# Altium schematic sheet lv_io.SchDoc(lv_io)
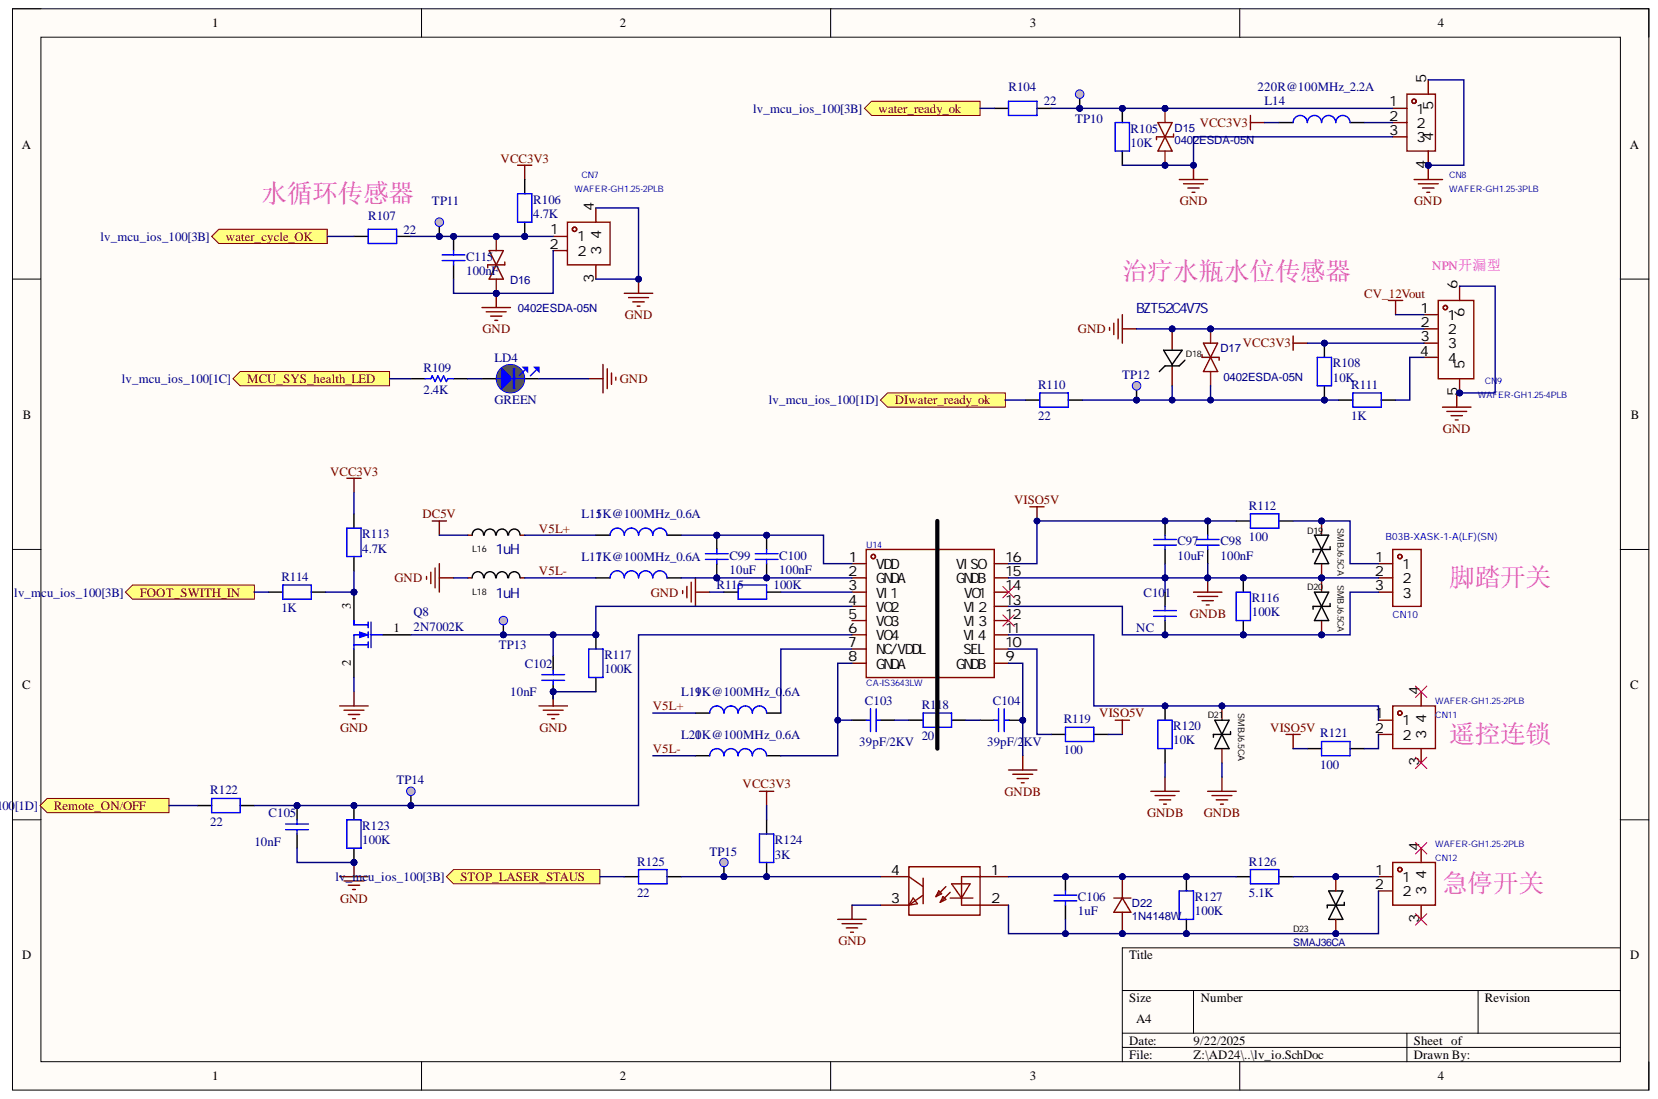
[diagram p0: lv_io.SchDoc]

## Parts
C97  10uF  [C0805]
C98  100nF  [C0603]
C99  10uF  [C0805]
C100  100nF  [C0603]
C101  NC  [C0603]
C102  10nF  [C0603]
C103  39pF/2KV  [1206]
C104  39pF/2KV  [1206]
C105  10nF  [C0603]
C106  1uF  [C0603]
C115  100nF  [C0603]
CN7  WAFER-GH1.25-2PLB  [CONN-TH_2P-P1.25_XUNPU_WAFER-GH1.25-2PLB]
CN8  WAFER-GH1.25-3PLB  [CONN-SMD_3P-P1.25_XUNPU_WAFER-GH1.25-3PLB]
CN9  WAFER-GH1.25-4PLB  [CONN-SMD_4P-P1.25_XUNPU_WAFER-GH1.25-4PLB]
CN10  B03B-XASK-1-A(LF)(SN)  [CONN-TH_B03B-XASK-1-A-LF-SN]
CN11  WAFER-GH1.25-2PLB  [CONN-TH_2P-P1.25_XUNPU_WAFER-GH1.25-2PLB]
CN12  WAFER-GH1.25-2PLB  [CONN-TH_2P-P1.25_XUNPU_WAFER-GH1.25-2PLB]
D15  0402ESDA-05N  [D0402-BI]
D16  0402ESDA-05N  [D0402-BI]
D17  0402ESDA-05N  [D0402-BI]
D18  BZT52C4V7S  [SOD-323_L1.7-W1.3-LS2.5-FD]
D19  SMBJ6.5CA  [SMB_L4.6-W3.6-LS5.3-BI]
D20  SMBJ6.5CA  [SMB_L4.6-W3.6-LS5.3-BI]
D21  SMBJ6.5CA  [SMB_L4.6-W3.6-LS5.3-BI]
D22  1N4148W  [SOD-123_L2.8-W1.8-LS3.7-RD]
D23  SMAJ36CA  [SMA_L4.4-W2.6-LS5.0-BI]
L14  220R@100MHz_2.2A  [L0603]
L15  1K@100MHz_0.6A  [L0603]
L16  1uH  [R0805]
L17  1K@100MHz_0.6A  [L0603]
L18  1uH  [R0805]
L19  1K@100MHz_0.6A  [L0603]
L20  1K@100MHz_0.6A  [L0603]
LD4  value GREEN  [LED_0603_GREEN]  (hidden comment: LED_green)
Q8  2N7002K  [SOT-23(SOT-23-3)]
R104  22  [R0402]
R105  10K  [R0603]
R106  4.7K  [R0402]
R107  22  [R0402]
R108  10K  [R0603]
R109  2.4K  [R0402]
R110  22  [R0402]
R111  1K  value 10K  [R0603]
R112  100  [R0603]
R113  4.7K  [R0402]
R114  1K  [R0402]
R115  100K  [R0402]
R116  100K  [R0603]
R117  100K  [R0402]
R118  20  [0805]
R119  100  [R0603]
R120  10K  [R0603]
R121  100  [R0603]
R122  22  [R0402]
R123  100K  [R0402]
R124  3K  [R0402]
R125  22  [R0402]
R126  5.1K  [R0603]
R127  100K  [R0603]
TP10  Test Point  [TP_SMD_1MM]  (value not drawn)  (no BOM)
TP11  Test Point  [TP_SMD_1MM]  (value not drawn)  (no BOM)
TP12  Test Point  [TP_SMD_1MM]  (value not drawn)  (no BOM)
TP13  Test Point  [TP_SMD_1MM]  (value not drawn)  (no BOM)
TP14  Test Point  [TP_SMD_1MM]  (value not drawn)  (no BOM)
TP15  Test Point  [TP_SMD_1MM]  (value not drawn)  (no BOM)
U14  CA-IS3643LW  [SOIC-16_L10.3-W7.5-P1.27-LS10.3-BL-1]
U15  EL817S1(C)(TU)-F  [OPTO-SMD-4_L4.6-W6.5-P2.54-LS10.3-TL]  (value not drawn)

## Ports
DIwater_ready_ok, FOOT_SWITH_IN, MCU_SYS_health_LED, Remote_ON/OFF, STOP_LASER_STAUS, water_cycle_OK, water_ready_ok

## Nets
CV_12Vout: CN9.1
DC5V: L16.1
GND: C102.2 C105.2 C115.1 CN7.2 CN7.3 CN7.4 CN8.3 CN8.4 CN8.5 CN9.2 CN9.5 CN9.6 D15.1 D16.1 D17.1 D18.2 L18.1 LD4.2 Q8.2 R105.1 R115.2 R117.1 R123.1 U15.3
GNDB: C97.2 C98.1 C101.2 C104.2 CN10.2 D19.1 D20.2 D21.1 R116.2 R120.1 U14.9(GNDB) U14.15(GNDB)
VCC3V3: CN9.3 L14.2 R106.1 R108.1 R113.2 R124.2
VISO5V: C97.1 C98.2 R112.2 R119.1 R121.1 U14.16(VISO)
DIwater_ready_ok: R110.1 ; port DIwater_ready_ok
FOOT_SWITH_IN: R114.2 ; port FOOT_SWITH_IN
MCU_SYS_health_LED: R109.2 ; port MCU_SYS_health_LED
Remote_ON/OFF: R122.1 ; port Remote_ON/OFF
STOP_LASER_STAUS: R125.1 ; port STOP_LASER_STAUS
V5L+: L15.2 L16.2 L19.2
V5L-: L17.2 L18.2 L20.2
water_cycle_OK: R107.1 ; port water_cycle_OK
water_ready_ok: R104.1 ; port water_ready_ok
NetC99_1: C99.1 C100.2 L15.1 U14.1(VDD)
NetC99_2: C99.2 C100.1 L17.1 U14.2(GNDA)
NetC101_1: C101.1 CN10.3 D20.1 R116.1 U14.13(VI2)
NetC102_1: C102.1 Q8.1 R117.2 TP13.1 U14.4(VO2)
NetC103_1: C103.1 L20.1 U14.8(GNDA)
NetC103_2: C103.2 R118.2
NetC104_1: C104.1 R118.1
NetC105_1: C105.1 R122.2 R123.2 TP14.1 U14.6(VO4)
NetC106_1: C106.1 CN12.2 D22.2 D23.2 R127.2 U15.2
NetC106_2: C106.2 D22.1 R126.2 R127.1 U15.1
NetC115_2: C115.2 CN7.1 D16.2 R106.2 R107.2 TP11.1
NetCN8_1: CN8.1 D15.2 R104.2 R105.2 TP10.1
NetCN8_2: CN8.2 L14.1
NetCN9_4: CN9.4 R111.2
NetCN10_1: CN10.1 D19.2 R112.1
NetCN11_1: CN11.1 D21.2 R120.2 U14.11(VI4)
NetCN11_2: CN11.2 R121.2
NetCN12_1: CN12.1 D23.1 R126.1
NetD17_2: D17.2 D18.1 R108.2 R110.2 R111.1 TP12.1
NetL19_1: L19.1 U14.7(NC/VDDL)
NetLD4_1: LD4.1 R109.1
NetQ8_3: Q8.3 R113.1 R114.1
NetR115_1: R115.1 U14.3(VI1)
NetR119_2: R119.2 U14.10(SEL)
NetR124_1: R124.1 R125.2 TP15.1 U15.4

Not connected: CN11 3 4; CN12 3 4; U14 5(VO3) 12(VI3) 14(VO1)

## Library data of the parts
C97 = Capacitor_1: 50V, X5R, 20%; Manufacturer: muRata; Manufacturer_Part_Number: GRM188R61E106MA73D; Component Kind: Standard; ComponentLink1Description: Yageo_Capacitor_X5R-series.pdf; ComponentLink1URL: http://tunmcdsvn.tun.st.com/Component_Datasheet_Library/Trunk/Capacitors/Yageo_Multilayer_capacitor_X5R_series_100pF_to_100uF; Library Name: HiPin_Library_AD.IntLib
C98 C100 C101 C115 = Capacitor_1: 50V, X7R, 10%; Manufacturer: muRata; Manufacturer_Part_Number: GRM188R71H104KA93D; Component Kind: Standard; ComponentLink1Description: Yageo_Capacitor_X5R-series.pdf; ComponentLink1URL: http://tunmcdsvn.tun.st.com/Component_Datasheet_Library/Trunk/Capacitors/Yageo_Multilayer_capacitor_X5R_series_100pF_to_100uF; Library Name: HiPin_Library_AD.IntLib
C99 = Capacitor_1: 50V, X5R, 20%; Manufacturer: muRata; Manufacturer_Part_Number: GRM21BR61H106KE43L; Component Kind: Standard; ComponentLink1Description: Yageo_Capacitor_X5R-series.pdf; ComponentLink1URL: http://tunmcdsvn.tun.st.com/Component_Datasheet_Library/Trunk/Capacitors/Yageo_Multilayer_capacitor_X5R_series_100pF_to_100uF; Library Name: HiPin_Library_AD.IntLib
C102 C105 = Capacitor_1: 50V, X5R, 10%; Manufacturer: muRata; Manufacturer_Part_Number: GRM188R61H105KAALD; Component Kind: Standard; ComponentLink1Description: Yageo_Capacitor_X5R-series.pdf; ComponentLink1URL: http://tunmcdsvn.tun.st.com/Component_Datasheet_Library/Trunk/Capacitors/Yageo_Multilayer_capacitor_X5R_series_100pF_to_100uF; Library Name: HiPin_Library_AD.IntLib
C103 C104 = Capacitor_3: 2KV, NP0, 5%; Internal_Part_Number: MCD-00008; Manufacturer: YAGEO; Manufacturer_Part_Number: CC1206JKNPODBN390; Component Kind: Standard; Library Name: HiPin_Library_AD.IntLib
C106 = Capacitor_1: 50V, X5R, 10%; Manufacturer: YAGEO; Manufacturer_Part_Number: CC0603KRX7R9BB105; Component Kind: Standard; Library Name: HiPin_Library_AD.IntLib; Package: C0603
CN7 CN11 CN12 = WAFER-GH1.25-2PLB: 插座系列: GH,  立贴结构: 1x2P, 间距: 1.25mm; Manufacturer: XUNPU(讯普); Add into BOM: yes; Current Rating: 1A; Number of PINs Per Row: 2; Plastic Material: LCP; Supplementary Features: 辅助焊脚; Voltage Rating: 125V; X-Length of Bottom Edge on Board (Spacing Line): 5.75mm; Y-Width of Bottom Edge on Board: 4.95mm; Z-Height of the Board: 4.2mm; Color: 米色; Component Kind: Standard; Contact Material: 黄铜; Contact Plating: -; Convert to PCB: yes; Datasheet: https://atta.szlcsc.com/upload/public/pdf/source/20220527/44F824AAC8C2B617205E15955FF9C23D.pdf; Device: WAFER-GH1.25-2PLB; Flame Retardant Rating: -; JLCPCB Part Class: Extended Part; LCSC Part Name: 1x2P 间距:1.25mm 立贴 系列:GH; Library Name: HiPin_Library_AD.IntLib; Manufacturer Part: WAFER-GH1.25-2PLB; Mounting Type: 立贴; Number of Pins: 2P; Number of Rows: 1; Operating Temperature: -25℃~+85℃; PCB3D: CONN-TH_2P-P1.25_XUNPU_WAFER-GH1.25-2PLB; Pins Structure: 1x2P; Pitch: 1.25mm; Reference Series: GH; Row Spacing: -; Supplier: LCSC; Supplier Footprint: SMD,P=1.25mm; Supplier Part: C3029368; Symbol: WAFER-GH1.25-2PLB
CN8 = WAFER-GH1.25-3PLB: 插座系列: GH,  立贴结构: 1x3P, 间距: 1.25mm; Manufacturer: XUNPU(讯普); Add into BOM: yes; Current Rating: 1A; Number of PINs Per Row: 3; Plastic Material: LCP; Supplementary Features: 辅助焊脚; Voltage Rating: 125V; X-Length of Bottom Edge on Board (Spacing Line): 7mm; Y-Width of Bottom Edge on Board: 4.95mm; Z-Height of the Board: 4.2mm; Color: 米色; Component Kind: Standard; Contact Material: 黄铜; Contact Plating: -; Convert to PCB: yes; Datasheet: https://atta.szlcsc.com/upload/public/pdf/source/20220527/44F824AAC8C2B617205E15955FF9C23D.pdf; Device: WAFER-GH1.25-3PLB; Flame Retardant Rating: -; JLCPCB Part Class: Extended Part; LCSC Part Name: 1x3P 间距:1.25mm 立贴 系列:GH; Library Name: HiPin_Library_AD.IntLib; Manufacturer Part: WAFER-GH1.25-3PLB; Mounting Type: 立贴; Number of Pins: 3P; Number of Rows: 1; Operating Temperature: -25℃~+85℃; PCB3D: CONN-SMD_3P-P1.25_XUNPU_WAFER-GH1.25-3PLB; Pins Structure: 1x3P; Pitch: 1.25mm; Reference Series: GH; Row Spacing: -; Supplier: LCSC; Supplier Footprint: SMD,P=1.25mm; Supplier Part: C3029369; Symbol: WAFER-GH1.25-3PLB
CN9 = WAFER-GH1.25-4PLB: 插座系列: GH,  立贴结构: 1x4P, 间距: 1.25mm; Manufacturer: XUNPU(讯普); Add into BOM: yes; Current Rating: 1A; Number of PINs Per Row: 4; Plastic Material: LCP; Supplementary Features: 辅助焊脚; Voltage Rating: 125V; X-Length of Bottom Edge on Board (Spacing Line): 8.25mm; Y-Width of Bottom Edge on Board: 4.95mm; Z-Height of the Board: 4.2mm; Color: 米色; Component Kind: Standard; Contact Material: 黄铜; Contact Plating: -; Convert to PCB: yes; Datasheet: https://atta.szlcsc.com/upload/public/pdf/source/20220527/44F824AAC8C2B617205E15955FF9C23D.pdf; Device: WAFER-GH1.25-4PLB; Flame Retardant Rating: -; JLCPCB Part Class: Extended Part; LCSC Part Name: 1x4P 间距:1.25mm 立贴 系列:GH; Library Name: HiPin_Library_AD.IntLib; Manufacturer Part: WAFER-GH1.25-4PLB; Mounting Type: 立贴; Number of Pins: 4P; Number of Rows: 1; Operating Temperature: -25℃~+85℃; PCB3D: CONN-TH_4P-P1.25_XUNPU_WAFER-GH1.25-4PLB; Pins Structure: 1x4P; Pitch: 1.25mm; Reference Series: GH; Row Spacing: -; Supplier: LCSC; Supplier Footprint: SMD,P=1.25mm; Supplier Part: C3029370; Symbol: WAFER-GH1.25-4PLB
CN10 = B03B-XASK-1-A(LF)(SN): 插座XA2.5mm,3A,H8.8mm; Manufacturer: JST; Add into BOM: yes; Flame Retardant Rating: UL94V-0; Number of PINs Per Row: 3; Pins Structure: 1x3P; Pitch: 2.5mm; Plastic Material: 玻纤增强PA66; Rated Voltage (Max): 250V; Reference Series: XA; Supplementary Features: 带柱；打K脚; X-Length of Bottom Edge on Board (Spacing Line): 10mm; Y-Width of Bottom Edge on Board: 6.4mm; Z-Height of the Board: 8.8mm; Colour: 白色; Component Kind: Standard; Contact Material: 铜合金; Contact Plating: 锡; Convert to PCB: yes; Current Rating (Max): 3A; Datasheet: https://atta.szlcsc.com/upload/public/pdf/source/20200311/C495727_AFFD619C34763440ABB8D8A2B6067F44.pdf; Device: B03B-XASK-1-A(LF)(SN); JLCPCB Part Class: Extended Part; LCSC Part Name: 1x3P 间距:2.5mm 直插 系列:XA; Library Name: HiPin_Library_AD.IntLib; Manufacturer Part: B03B-XASK-1-A(LF)(SN); Mounting Style: 直插; Number of Pins: 3P; Number of Rows: 1; Operating Temperature Range: -25℃~+85℃; PCB3D: CONN-TH_B03B-XASK-1-A-LF-SN; Row Spacing: -; Supplier: LCSC; Supplier Footprint: 插件,P=2.5mm; Supplier Part: C264992; Symbol: B03B-XASK-1-A(LF)(SN)
D15-D17 = 0402ESDA-05N: BOM_Manufacturer: Bourne Semicon (Shenzhen); Contributor: LCSC; BOM_JLCPCB Part Class: Extended Part; BOM_Manufacturer Part: 0402ESDA-05N; BOM_Supplier: LCSC; BOM_Supplier Part: C316049; Component Kind: Standard; Library Name: HiPin_Library_AD.IntLib; spicePre: D; spiceSymbolName: 0402ESDA-05N
D18 = BZT52C4V7S: Manufacturer: CJ(江苏长电/长晶); Manufacturer Part: BZT52C6V2; Add into BOM: yes; Device: BZT52C6V2_C2910064; Diode Configuration: 独立式; Power Dissipation: 500mW; Reverse Leakage Current (Ir): 3uA@4V; Zener Impedance (Zzt): 10Ω; Zener Voltage (Nom): 6.2V; Zener Voltage (Range): 5.8V~6.6V; Component Kind: Standard; Convert to PCB: yes; Datasheet: https://atta.szlcsc.com/upload/public/pdf/source/20211105/0A7AF84D57D732370551C8DD7A10F563.pdf; JLCPCB Part Class: Extended Part; LCSC Part Name: BZT52C6V2; Library Name: HiPin_Library_AD.IntLib; Name: BZT52C6V2; Supplier: LCSC; Supplier Footprint: SOD-123; Supplier Part: C2910064; Symbol: BZT52C6V2_C2910064; Tolerance: -
D19-D21 = SMBJ18CA_C113991: Vrwm6.5, 最大钳位电压11.2V， 击穿电压7.98V; Manufacturer: MDD; Add into BOM: yes; Breakdown Voltage: 20V; Maximum Clamping Voltage: 29.2V; Peak Pulse Current (Ipp)@10/1000us: 20.5A; Reverse Stand-Off Voltage (Vrwm): 18V; Symbol: SMBJ18CA-C113991; Type: TVS; Component Kind: Standard; Convert to PCB: yes; Datasheet: https://atta.szlcsc.com/upload/public/pdf/source/20230314/C8F66EF07395B88C11214ABD10019202.pdf; Device: SMBJ18CA_C113991; JLCPCB Part Class: Extended Part; LCSC Part Name: SMBJ18CA 双向TVS Vrwm:18V; Library Name: HiPin_Library_AD.IntLib; Manufacturer Part: SMBJ6.5CA; Name: SMBJ6.5CA; PCB3D: SMB_L4.6-W3.6-LS5.3-BI; Supplier: LCSC; Supplier Footprint: SMB(DO-214AA); Supplier Part: C113991
D22 = 1N4148W-C84367: BOM_Manufacturer: MDD; BOM_JLCPCB Part Class: Extended Part; BOM_Manufacturer Part: 1N4148W; BOM_Supplier: LCSC; BOM_Supplier Part: C84367; Component Kind: Standard; Library Name: HiPin_Library_AD.IntLib; spicePre: D; spiceSymbolName: 1N4148W-C84367
D23 = SMAJ6.8CA_C406209: 极性: 双向  反向截止电压(Vrwm): 36V  钳位电压: 58.1V  峰值脉冲电流(Ipp): 6.9A; Manufacturer: BORN(�����뵼��); Add into BOM: yes; Reverse Stand-Off Voltage (Vrwm): 6.8V; Type: TVS; Component Kind: Standard; Convert to PCB: yes; Datasheet: https://atta.szlcsc.com/upload/public/pdf/source/20190726/C406209_A574BC8626299E1E05AC1B67D2991239.pdf; JLCPCB Part Class: Extended Part; LCSC Part Name: ˫��TVS 6.8V��ֹ; Library Name: HiPin_Library_AD.IntLib; Manufacturer Part: SMAJ36CA; Supplier: LCSC; Supplier Footprint: SMA(DO-214AC); Supplier Part: C406209
L14 = MCD-00047: SMD Chip ferrite bead, 220R@100MHz +/-25%,2.2A, 50mohm; Manufacturer: Sunlord; Manufacturer_Part_Number: UPZ1608U221-2R2TF; Database Table Name: MCD_PARTS$; Component Kind: Standard; ComponentLink1Description: Datasheet; ComponentLink1URL: http://tunmcdsvn.tun.st.com/Component_Datasheet_Library/Trunk/Inductors/TaiTech_Bead_FCM1608_series; Internal_Part_Number: MCD-00047; Library Name: MCD_PARTS_database.DbLib
L15 L17 L19 L20 = MCD-00047: ±25%, 350mΩ, 600mA; Manufacturer: muRata; Manufacturer_Part_Number: BLM18HE102SN1D; Database Table Name: MCD_PARTS$; Component Kind: Standard; ComponentLink1Description: Datasheet; ComponentLink1URL: http://tunmcdsvn.tun.st.com/Component_Datasheet_Library/Trunk/Inductors/TaiTech_Bead_FCM1608_series; Library Name: MCD_PARTS_database.DbLib
L16 L18 = WPN252012H1R0MT: 1uH ±20% 0.7A 100mΩ; Manufacturer Part: MLZ2012M1R0HT000; Component Kind: Standard; Library Name: HiPin_Library_AD.IntLib
LD4 = LED_green: LED, Green, 0603; Internal_Part_Number: MCD-00050; Manufacturer: EVERLIGHT; Manufacturer_Part_Number: 19-213SYGC/S530-E2/TR8; Part_Type: Display; ECCN_EU: NEC; ECCN_US: EAR99; Provided_By: SUBCO; Component Kind: Standard; ComponentLink1Description: Datasheet; ComponentLink1URL: http://tunmcdsvn.tun.st.com/Component_Datasheet_Library/Trunk/Display/Everlight_SMD-LED-GREEN_19-213SYGC-S530-XX-TR8-v3.doc; Library Name: mb1367.IntLib; Package: LED 0603; Status: Released
Q8 = MOSFET-N: N沟道,60V,0.115A,7Ω@10V; Manufactu: CJ江苏长电; ManufacturerNumber: 2N7002; Component Kind: Standard; Library Name: HiPin_Library_AD.IntLib; Package: SOT-23; SuppliersPartNumber: C8545
R104 R106 R107 R110 R112-R119 R121-R127 = RES: 1%; Value: 10K; Component Kind: Standard; Library Name: HiPin_Library_AD.IntLib
R105 R108 R111 R120 = RES: 1%; Component Kind: Standard; Library Name: HiPin_Library_AD.IntLib
  R105 R108 R120: Value: 10K
R109 = Resistor_1: 1%,1/16W; Manufacturer: YAGEO; Manufacturer_Part_Number: RC0402FR-072K4L; Component Kind: Standard; ComponentLink1Description: Datasheet; ComponentLink1URL: http://tunmcdsvn.tun.st.com/Component_Datasheet_Library/Trunk/Resistors/Yageo_Resistor_RC0402-series; Library Name: mb1367.IntLib
TP10-TP15 = MCD-00074: Test Point 1mm; Part_Type: Mechanical; Database Table Name: MCD_PARTS$; ComponentLink1Description: Datasheet; ComponentLink1URL: http://na; ECCN_EU: n/a; ECCN_US: n/a; Internal_Part_Number: MCD-00074; Library Name: MCD_PARTS_database.DbLib; Manufacturer: n/a; Manufacturer_Part_Number: n/a; Provided_By: n/a; Status: Released
U14 = CA-IS3643LW: Manufacturer: Chipanalog(川土微); Add into BOM: yes; CMTI(kV/us): 150kV/us; Data Rate(Max): 100Mbps; Default Output: 低电平; Isolation Voltage(Vrms): 5000; Number of Forward Channels: 1; Number of Reverse Channels: 3; Output Voltage: 5V；3.3V; Voltage - Supply: 3V~5.5V; Component Kind: Standard; Convert to PCB: yes; Datasheet: https://item.szlcsc.com/datasheet/CA-IS3643LW/5710364.html; Device: CA-IS3643LW; JLCPCB Part Class: Extended Part; LCSC Part Name: CA‐IS36xx 高性能，5kVRMS 隔离耐压，集成高效率、低辐射 DC‐DC 转换器 的数字隔离器; Library Name: HiPin_Library_AD.IntLib; Manufacturer Part: CA-IS3643LW; Operating Temperature: -40℃~+125℃; PCB3D: SOIC-16_L10.3-W7.5-P1.27-LS10.3-BL-1; Supplier: LCSC; Supplier Footprint: SOIC-16; Supplier Part: C5121944; Symbol: CA-IS3643LW
U15 = EL817S1(C)(TU)-F: Manufacturer: EVERLIGHT(亿光); Add into BOM: yes; Collector-Emitter Saturation Voltage (Vce(sat)@Ic,IF): 100mV@1mA,20mA; Fall time: 18us@2mA,100Ω; Forward Voltage: 1.2V; Input voltage type: DC; Isolation Voltage(rms): 5kV; Load Voltage: 35V; Number of Outputs: 1; Output Current: 50mA; Output Type: 光电三极管; Reverse Voltage: 6V; Component Kind: Standard; Convert to PCB: yes; Datasheet: https://atta.szlcsc.com/upload/public/pdf/source/20181026/C323798_FBCE14484708FE0807B9A090D624C4F0.pdf; Device: EL817S1(C)(TU)-F; JLCPCB Part Class: Basic Part; LCSC Part Name: DC输入 隔离电压(rms):5000V; Library Name: HiPin_Library_AD.IntLib; Manufacturer Part: EL817S1(C)(TU)-F; Operating Temperature: -55℃~+110℃; Rise time: 18us@2mA,100Ω; Supplier: LCSC; Supplier Footprint: SMD-4P; Supplier Part: C106900; Symbol: EL817S1(C)(TU)-F
